# Altium schematic sheet analog_frontend.SchDoc(analog_frontend)
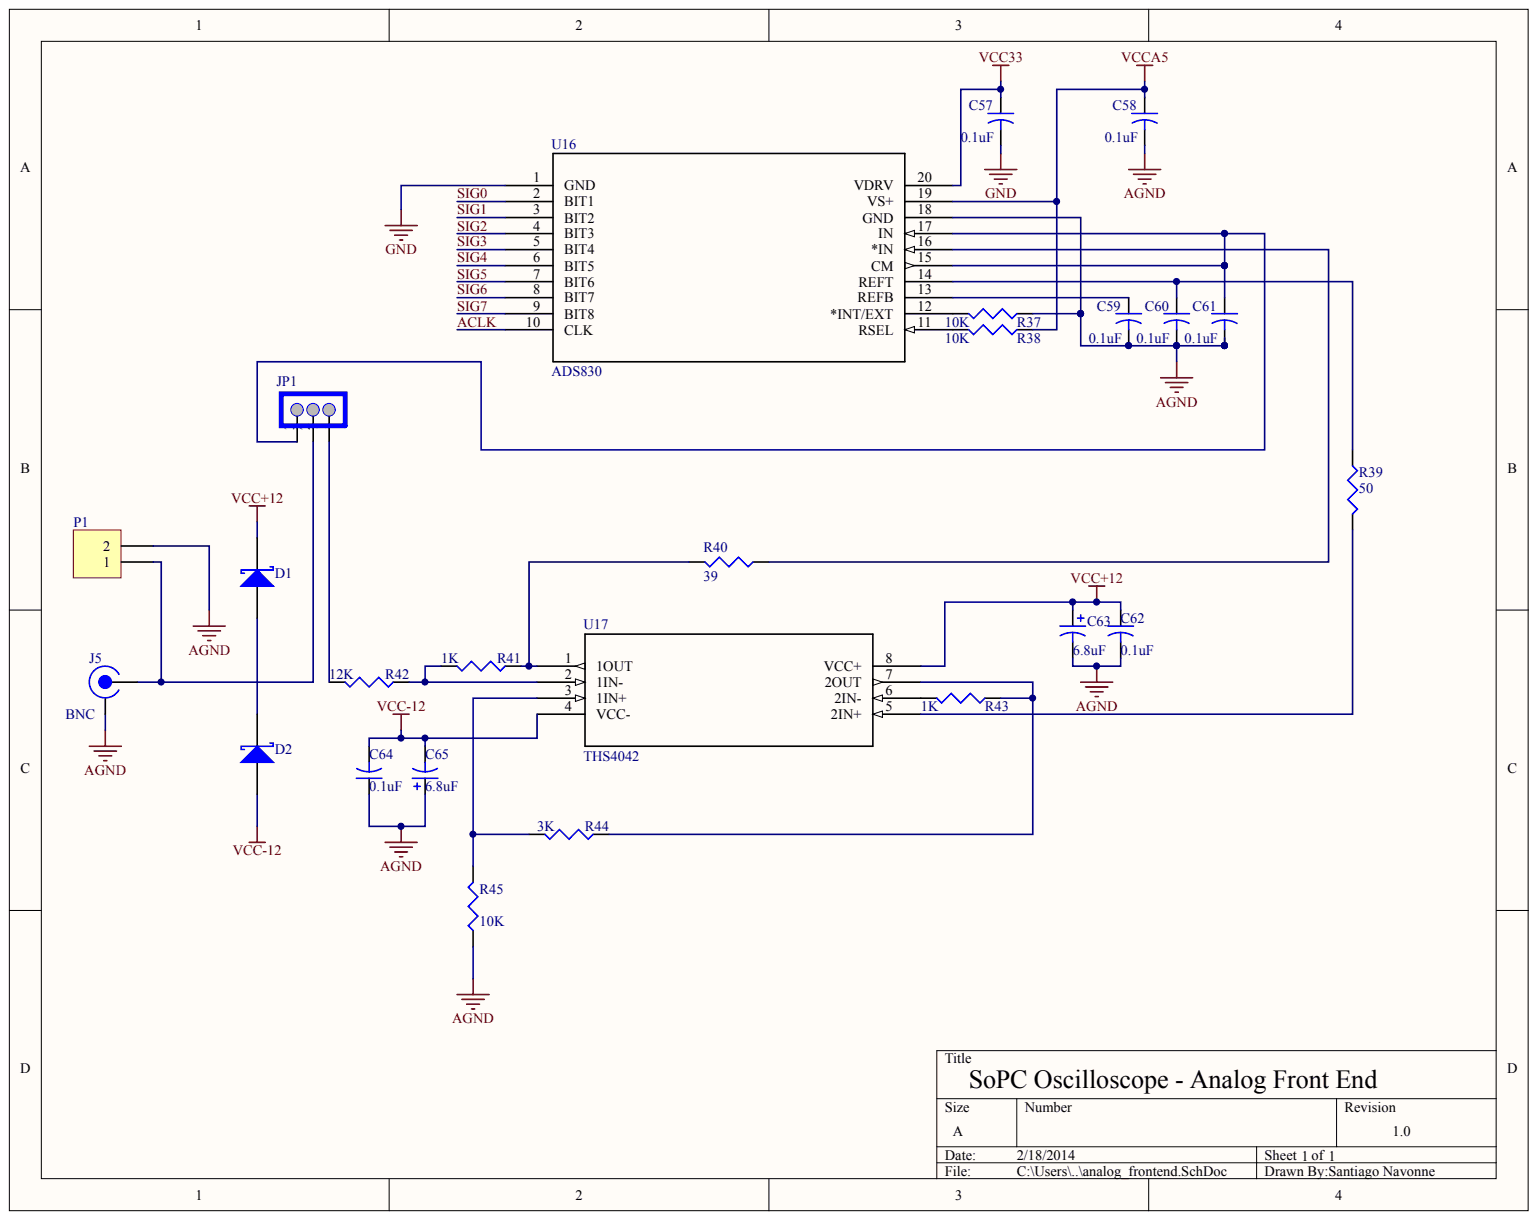
[diagram p0: analog_frontend.SchDoc]

## Parts
C57  value 0.1uF  (hidden comment: Cap2)
C58  value 0.1uF  (hidden comment: Cap2)
C59  value 0.1uF  (hidden comment: Cap2)
C60  value 0.1uF  (hidden comment: Cap2)
C61  value 0.1uF  (hidden comment: Cap2)
C62  value 0.1uF  (hidden comment: Cap2)
C63  value 6.8uF  (hidden comment: Cap Pol3)
C64  value 0.1uF  (hidden comment: Cap2)
C65  value 6.8uF  (hidden comment: Cap Pol3)
D1  D Schottky  (value not drawn)
D2  D Schottky  (value not drawn)
J5  BNC
JP1  AIN  (value not drawn)
P1  Header 2  (value not drawn)
R37  value 10K  (hidden comment: Res3)
R38  value 10K  (hidden comment: Res3)
R39  value 50  (hidden comment: Res3)
R40  value 39  (hidden comment: Res3)
R41  value 1K  (hidden comment: Res3)
R42  value 12K  (hidden comment: Res3)
R43  value 1K  (hidden comment: Res3)
R44  value 3K  (hidden comment: Res3)
R45  value 10K  (hidden comment: Res3)
U16  ADS830
U17  THS4042

## Nets
AGND: C58.2 C59.2 C60.2 C61.2 C62.2 C63.2 C64.1 C65.1 J5.2 P1.2 R37.1 R45.1 U16.18(GND)
GND: C57.2 U16.1(GND)
VCC33: C57.1 U16.20(VDRV)
VCC+12: C62.1 C63.1 D1.2 U17.8(VCC+)
VCC-12: C64.2 C65.2 D2.1 U17.4(VCC-)
VCCA5: C58.1 R38.1 U16.19(VS+)
ACLK: U16.10(CLK)
SIG0: U16.2(BIT1)
SIG1: U16.3(BIT2)
SIG2: U16.4(BIT3)
SIG3: U16.5(BIT4)
SIG4: U16.6(BIT5)
SIG5: U16.7(BIT6)
SIG6: U16.8(BIT7)
SIG7: U16.9(BIT8)
NetC59_1: C59.1 U16.13(REFB)
NetC60_1: C60.1 R39.1 U16.14(REFT)
NetC61_1: C61.1 JP1.3 U16.15(CM) U16.17(IN)
NetD1_1: D1.1 D2.2
NetJ5_1: J5.1 JP1.2 P1.1
NetJP1_1: JP1.1 R42.2
NetR37_2: R37.2 U16.12(*INT/EXT)
NetR38_2: R38.2 U16.11(RSEL)
NetR39_2: R39.2 U17.5(2IN+)
NetR40_1: R40.1 U16.16(*IN)
NetR40_2: R40.2 R41.1 U17.1(1OUT)
NetR41_2: R41.2 R42.1 U17.2(1IN-)
NetR43_1: R43.1 R44.1 U17.7(2OUT)
NetR43_2: R43.2 U17.6(2IN-)
NetR44_2: R44.2 R45.2 U17.3(1IN+)

## Library data of the parts
U16 = (no symbol name): Manufacturer: Texas Instruments; INDUSTRY_STD_PKG_TYPE: SSOP/QSOP; FAMILY_NAME: HIGH SPEED ADC (>10MSPS); PIN_COUNT: 20; PACKAGE_DESIGNATOR: DBQ; Datasheet URL: http://www.ti.com/lit/gpn/ADS830; GENERIC_PART_NUMBER: ADS830; RefDes: RefDes; Type: DEV; Value: Value
U17 = (no symbol name): Manufacturer_Part_Number: ths4042_d_8; Vendor: Texas Instruments; PACKAGE_DESIGNATOR: D; PIN_COUNT: 8; FAMILY_NAME: VOLTAGE FEEDBACK; INDUSTRY_STD_PKG_TYPE: SOIC; GENERIC_PART_NUMBER: THS4042; Datasheet URL: http://www.ti.com/lit/gpn/THS4042; Manufacturer: Texas Instruments; RefDes: RefDes; Type: DEV; Value: Value
C57-C65 D1 D2 J5 JP1 P1 R37-R45 = (no symbol name)
  C57-C62 C64: Published: 23-Sep-2002; PackageReference: CAPR5-4X5; PackageDescription: Capacitor; 2 Leads
  C63 C65: Published: 8-Jun-2000; LatestRevisionDate: 29-May-2009; LatestRevisionNote: IPC-7351 Footprint Added.; PackageReference: C0805; PackageDescription: Chip Capacitor
  D1 D2: Published: 8-Jun-2000; LatestRevisionDate: 17-Jul-2002; LatestRevisionNote: Re-released for DXP Platform.; PackageReference: SMB; PackageDescription: DO-214-AA/SMB; 2 C-Bend Leads; Body 5.3 x 3.6 mm, inc. leads (LxW); Code_JEDEC: DO-214-AA
  P1: LatestRevisionDate: 17-Jul-2002; LatestRevisionNote: Re-released for DXP Platform.
  R37-R45: Published: 8-Jun-2000; LatestRevisionDate: 29-May-2009; LatestRevisionNote: IPC-7351 Footprint Added.; PackageReference: J1-0603; PackageDescription: Chip Resistor
  C57-C65 D1 D2 P1 R37-R45: Publisher: Altium Limited
